# Altium schematic sheet mcu.SchDoc(mcu)
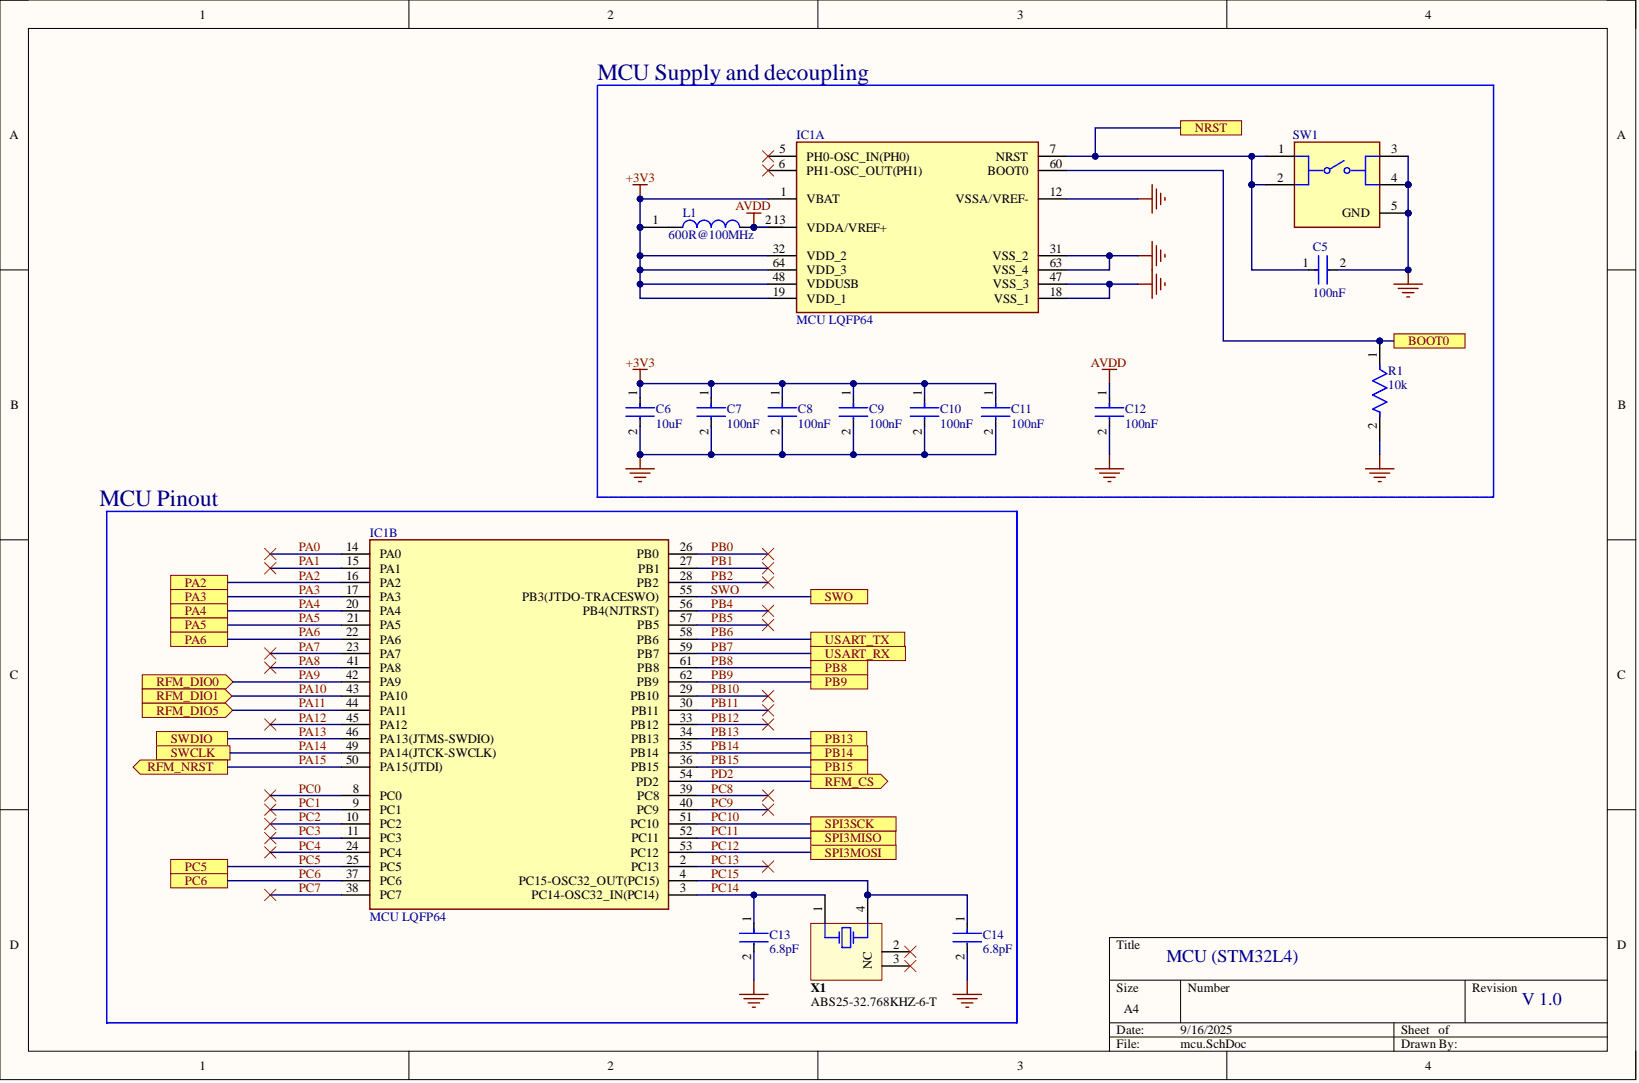
[diagram p0: mcu.SchDoc]

## Parts
C5  100nF
C6  10uF
C7  100nF
C8  100nF
C9  100nF
C10  100nF
C11  100nF
C12  100nF
C13  6.8pF
C14  6.8pF
IC1  MCU LQFP64  (parts A,B)
L1  600R@100MHz
R1  10k
SW1  KMR231GLFS  (value not drawn)
X1  ABS25-32.768KHZ-6-T

## Ports
BOOT0, NRST, PA2, PA3, PA4, PA5, PA6, PB8, PB9, PB13, PB14, PB15, PC5, PC6, RFM_CS, RFM_DIO0, RFM_DIO1, RFM_DIO5, RFM_NRST, SPI3MISO, SPI3MOSI, SPI3SCK, SWCLK, SWDIO, SWO, USART_RX, USART_TX

## Nets
+3V3: C6.1 C7.1 C8.1 C9.1 C10.1 C11.1 IC1.1(VBAT) IC1.19(VDD_1) IC1.32(VDD_2) IC1.48(VDDUSB) IC1.64(VDD_3) L1.1
AVDD: C12.1 IC1.13(VDDA/VREF+) L1.2
GND: C5.2 C6.2 C7.2 C8.2 C9.2 C10.2 C11.2 C12.2 C13.2 C14.2 IC1.12(VSSA/VREF-) IC1.18(VSS_1) IC1.31(VSS_2) IC1.47(VSS_3) IC1.63(VSS_4) R1.2 SW1.3 SW1.4 SW1.5(GND)
BOOT0: IC1.60(BOOT0) R1.1 ; port BOOT0
NRST: C5.1 IC1.7(NRST) SW1.1 SW1.2 ; port NRST
PA0: IC1.14(PA0)
PA1: IC1.15(PA1)
PA2: IC1.16(PA2) ; port PA2
PA3: IC1.17(PA3) ; port PA3
PA4: IC1.20(PA4) ; port PA4
PA5: IC1.21(PA5) ; port PA5
PA6: IC1.22(PA6) ; port PA6
PA7: IC1.23(PA7)
PA8: IC1.41(PA8)
PA9: IC1.42(PA9) ; port RFM_DIO0
PA10: IC1.43(PA10) ; port RFM_DIO1
PA11: IC1.44(PA11) ; port RFM_DIO5
PA12: IC1.45(PA12)
PA13: IC1.46(PA13(JTMS-SWDIO)) ; port SWDIO
PA14: IC1.49(PA14(JTCK-SWCLK)) ; port SWCLK
PA15: IC1.50(PA15(JTDI)) ; port RFM_NRST
PB0: IC1.26(PB0)
PB1: IC1.27(PB1)
PB2: IC1.28(PB2)
PB4: IC1.56(PB4(NJTRST))
PB5: IC1.57(PB5)
PB6: IC1.58(PB6) ; port USART_TX
PB7: IC1.59(PB7) ; port USART_RX
PB8: IC1.61(PB8) ; port PB8
PB9: IC1.62(PB9) ; port PB9
PB10: IC1.29(PB10)
PB11: IC1.30(PB11)
PB12: IC1.33(PB12)
PB13: IC1.34(PB13) ; port PB13
PB14: IC1.35(PB14) ; port PB14
PB15: IC1.36(PB15) ; port PB15
PC0: IC1.8(PC0)
PC1: IC1.9(PC1)
PC2: IC1.10(PC2)
PC3: IC1.11(PC3)
PC4: IC1.24(PC4)
PC5: IC1.25(PC5) ; port PC5
PC6: IC1.37(PC6) ; port PC6
PC7: IC1.38(PC7)
PC8: IC1.39(PC8)
PC9: IC1.40(PC9)
PC10: IC1.51(PC10) ; port SPI3SCK
PC11: IC1.52(PC11) ; port SPI3MISO
PC12: IC1.53(PC12) ; port SPI3MOSI
PC13: IC1.2(PC13)
PC14: C13.1 IC1.3(PC14-OSC32_IN(PC14)) X1.1
PC15: C14.1 IC1.4(PC15-OSC32_OUT(PC15)) X1.4
PD2: IC1.54(PD2) ; port RFM_CS
SWO: IC1.55(PB3(JTDO-TRACESWO)) ; port SWO

Not connected: IC1 5(PH0-OSC_IN(PH0)) 6(PH1-OSC_OUT(PH1)); X1 2(NC) 3

## Library data of the parts
C5 C7-C12 = CMP-13271-003801-1: Cap Ceramic 100nF 6.3V X5R ±10% SMD 0402 +85°C Paper T/R; Capacitance: 100nF; Case Code (Imperial): 0402; Case Code (Metric): 1005; Case/Package: 0402; Component Kind: Standard; Depth: 0.5mm; Dielectric: X5R; Height: 0.5mm; Lead Free: Lead Free; Length: 1mm; Library Name: Altium Content Vault; Max Operating Temperature: 85°C; Min Operating Temperature: -55°C; Mount: Surface Mount; Package Quantity: 1; Packaging: Tape and Reel; Radiation Hardening: No; Thickness: 0.022inch; Tolerance: 10%; Voltage Rating: 6.3V; Voltage Rating (DC): 6.3V; Width: 0.02inch
C6 = CMP-13271-000175-1: CL21 Series 0805 10uF 6.3V ±10% Tolerance X6S Multilayer Ceramic Chip Capacitor; Capacitance: 10uF; Case Code (Imperial): 0805; Case Code (Metric): 2012; Case/Package: 0805; Component Kind: Standard; Depth: 1.25mm; Dielectric: X6S; Height: 1.25mm; Lead Free: Lead Free; Length: 2mm; Library Name: Altium Content Vault; Max Operating Temperature: 105°C; Min Operating Temperature: -55°C; Mount: Surface Mount; Package Quantity: 1; Packaging: Tape and Reel; Radiation Hardening: No; Thickness: 0.055inch; Tolerance: 10%; Voltage Rating: 6.3V; Voltage Rating (DC): 6.3V; Width: 0.049inch
C13 C14 = CMP-2008-03876-2: Multi-Layer Ceramic Capacitor 6.8pF C0G  ±0.5pF 0402 Paper T/R; Voltage Rating: 50V; Capacitance: 6.8pF; Case Code (Imperial): 0402; Case Code (Metric): 1005; Case/Package: 0402; Component Kind: Standard; Depth: 0.5mm; Dielectric: C0G; Height: 0.5mm; Length: 1mm; Library Name: Altium Content Vault; Max Operating Temperature: 125°C; Min Operating Temperature: -55°C; Mount: Surface Mount; Package Quantity: 10000; Packaging: Tape and Reel; Radiation Hardening: No; Termination: SMD/SMT; Thickness: 0.022inch; Voltage Rating (DC): 50V; Width: 0.02inch
IC1 = STM32L476RGT6: Integrated Circuit; Manufacturer_Name: STMicroelectronics; Arrow Part Number: STM32L476RGT6; Arrow Price/Stock: https://www.arrow.com/en/products/stm32l476rgt6/stmicroelectronics?utm_currency=USD&region=nac; Component Kind: Standard; Datasheet Link: https://www.st.com/resource/en/datasheet/stm32l476rg.pdf; Height: 1.6mm; Library Name: SchLibL4.SchLib; Manufacturer_Part_Number: STM32L476RGT6; Mouser Part Number: 511-STM32L476RGT6; Mouser Price/Stock: https://www.mouser.co.uk/ProductDetail/STMicroelectronics/STM32L476RGT6?qs=o58qnh%2FCpu44V%252B7CaDZzCA%3D%3D
L1 = FCM1608KF-601T03: SMD Chip ferrite bead, 600R@100MHz +/-25%, 350mA, 500mohm DC, 0603, T=0.8mm; Manufacturer_Name: TAI-TECH; Component Kind: Standard; Datasheet Link: https://www.tai-tech.com.tw/_upload/files/FCM1608-Series.pdf; Height: 0.95mm; Library Name: STM32WL55_SchLib.SchLib; Manufacturer_Part_Number: FCM1608KF-601T03
R1 = CMP-2000-04517-2: RES SMD 10K OHM 0.1% 1/10W 0603; Manufacturer: Yageo; Manufacturer Part Number: RT0603BRE0710KL; Power Rating: 0.1W; Resistance: 10KΩ; Temperature Coefficient: 50 ppm/°C; Tolerance: 0.001; Value: 10KΩ; Voltage Rating: 75V; Automotive: No; Category: Res; Component Kind: Standard; ComponentLink2Description: Datasheet; ComponentLink2URL: http://www.yageo.com/documents/recent/PYu-RT_1-to-0.01_RoHS_L_9.pdf; Composition: Thin Film; Device Class L1: Passive Components; Device Class L2: Resistors; Device Class L3: Chip SMD Resistors; Digikey Description: RES SMD 10K OHM 0.1% 1/10W 0603; Footprint Url: http://www.yageo.com/exep/pages/download/literatures/PYu-R_Mount_10.pdf; Height: 0.55mm; Ipc Land Pattern Name: RESC16080X45; Lead Free: Yes; Library Name: Altium Content Vault; Mouser Description: Res Thin Film 0603 10K Ohm 0.1% 1/10W ±50ppm/°C Molded SMD SMD Paper T/R; Octopart: https://octopart.com/rt0603bre0710kl-yageo-1273959; Package: RT0603; RoHS: TRUE; Series: RT; Temperature: -55°C to +155°C; Temperature Range High: +155°C; Temperature Range Low: -55°C
SW1 = CMP-2000-06516-3: SWITCH TACTILE SPST-NO 0.05A 32V; Actuator Length: 0.5mm; Actuator Type: Standard; Contact Current Rating: 50mA; Contact Resistance: 100mR; Illumination Type: Non-Illuminated; Insulation Resistance: 1GR; Mechanical Life: 150000Cycles; Operating Force: 3N; Power Rating: 1W; Switch Function: Off-Mom; Switch Travel: 0.25mm; Throw Configuration: SPST; Case/Package: SMD/SMT; Component Kind: Standard; Contact Material: Silver; Contact Plating: Silver; Current Rating: 50mA; Depth: 4.6mm; Lead Free: Lead Free; Length: 4.6mm; Library Name: Altium Content Vault; Max Current Rating: 50mA; Max Operating Temperature: 85°C; Max Voltage Rating (DC): 32V; Min Operating Temperature: -40°C; Mount: Surface Mount; Orientation: Straight; Package Quantity: 7000; Packaging: Cut Tape; Radiation Hardening: No; REACH SVHC: No SVHC; Series: KMR2; Termination: Gull Wing; Voltage Rating (DC): 32V; Width: 2.8mm
X1 = CMP-2000-05036-1: Low Frequency Crystal, 32.768 KHz, 6 pF, +/- 20 ppm, -40 to 85 degC, 4-Pin SMD, RoHS, Tape and Reel; Brand: ABRACON; Case Height: 2.5mm; Case Length: 8mm; Case Width: 3.8mm; ESR: 50kOhms; Load Capacitance: 6pF; Tolerance: 20PPM; Component Kind: Standard; Datasheet URL: https://datasheet.ciiva.com/854/abs25-854528.pdf; Datasheet Version: Jun-17; Dimensions: 3.8mmWx8mmLx2.5mmH; Factory Pack Quantity: 3000; Frequency: 32.768kHz; Library Name: Altium Content Vault; Manufacturer: Abracon; Manufacturer URL: http://www.abracon.com/; Mounting Style: SMD/SMT; Operating Temperature Range: -40Cto+85C; Package / Case: 8mmx3.8mm; Package Description: 4-Pin Surface Mount Device, Body 8 x 3.8 mm, Pitch 5.5 mm; Package Reference: ABS25; Packaging: Reel; Product Category: Crystals; RoHS: Details; Series: ABS25; Termination Style: SMD/SMT; Type: LowFrequencyCrystal
